# Altium schematic sheet TIDA-00367_REV2.SchDoc(TIDA-00367_REV2)
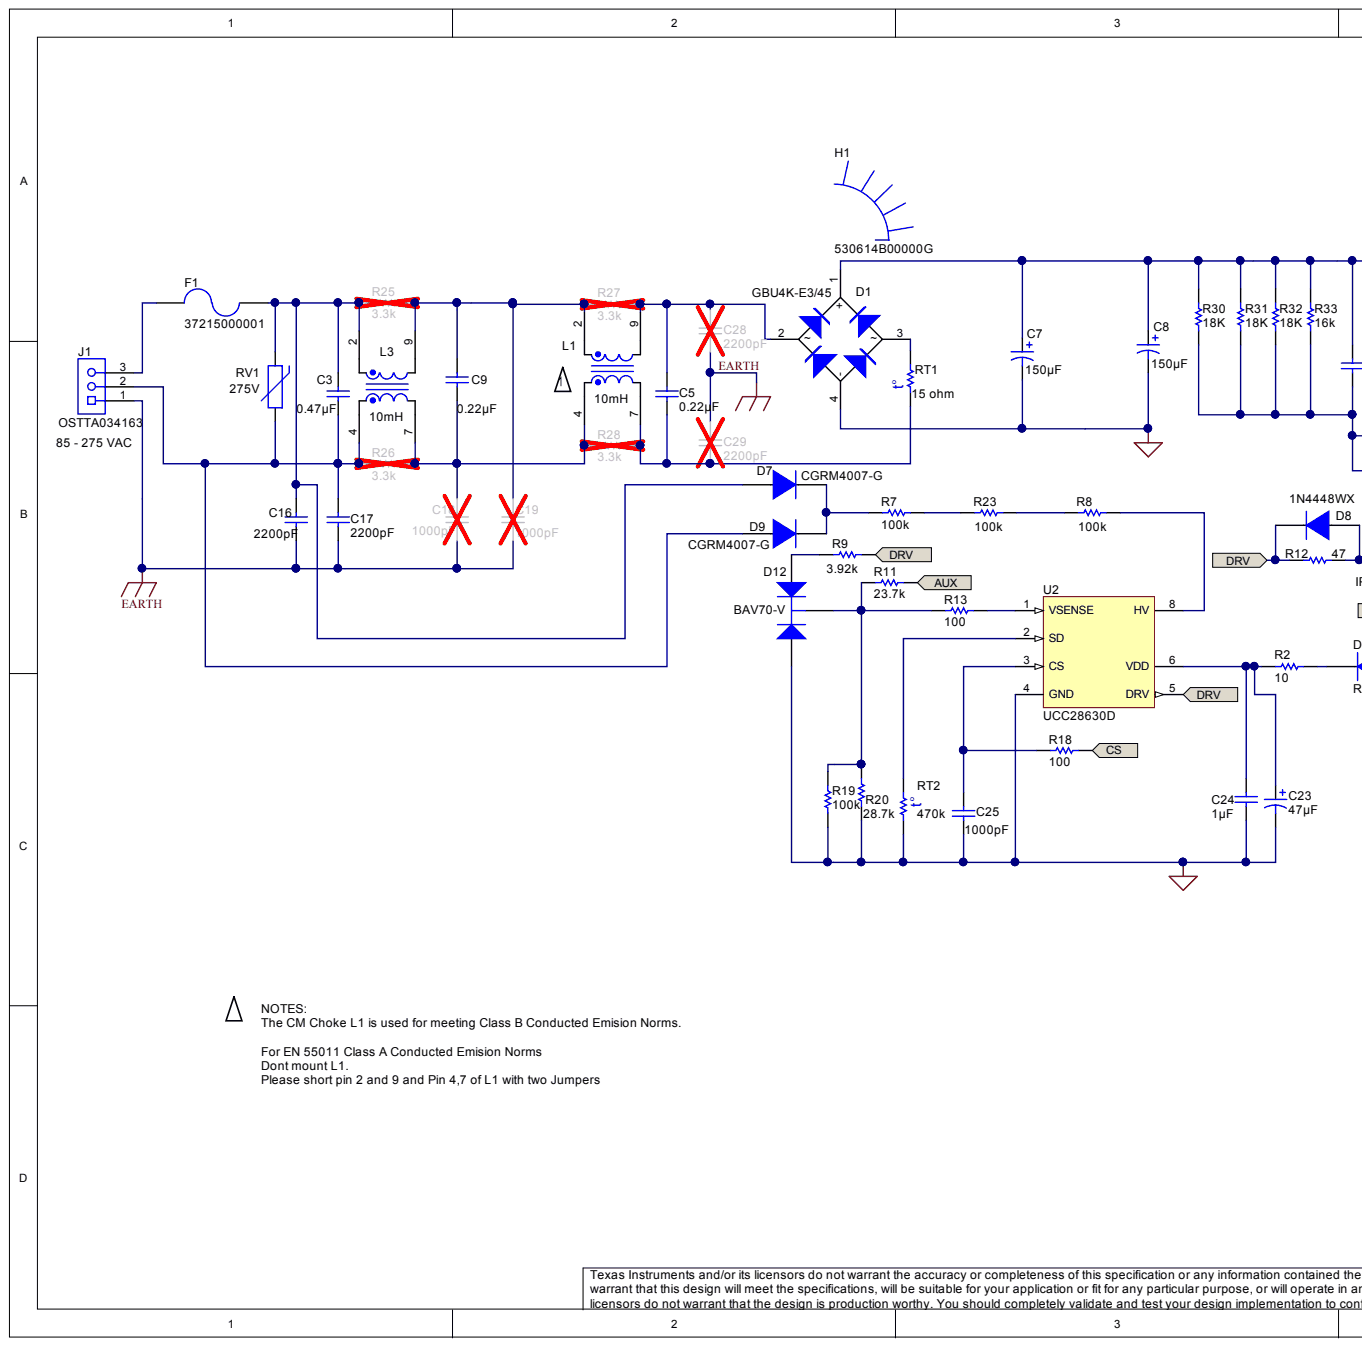
[diagram p0: TIDA-00367_REV2.SchDoc, left]
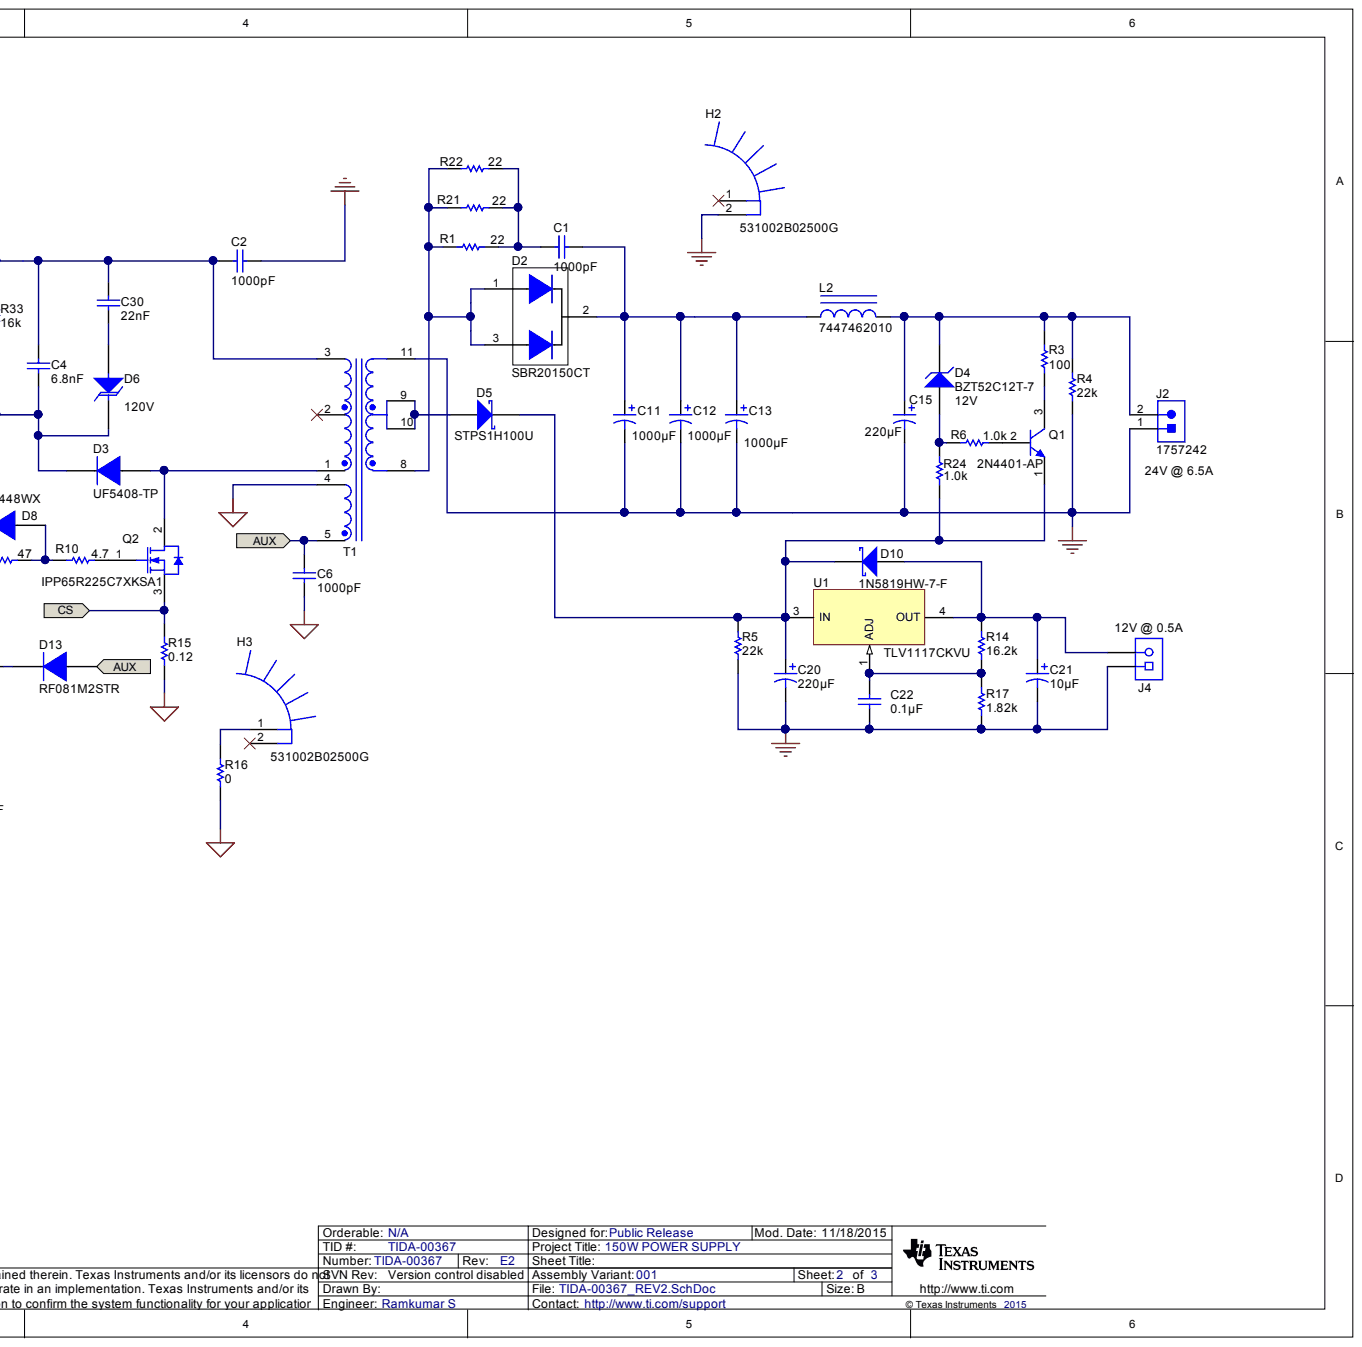
[diagram p1: TIDA-00367_REV2.SchDoc, right]

## Parts
C1  value 1000pF  [0805_HV]  (hidden comment: QMK212B7102KD-T)
C2  value 1000pF  [VY2_1250x500x1650]  (hidden comment: DE1E3KX102MA5BA01)
C3  ValueDisplayed: 0.47µF  [B32922_12.5mm]  (hidden comment: B32922C3474M)
C4  ValueDisplayed: 6.8nF  [VY2_1250x500x1650]  (hidden comment: RDER73A682K3K1H03B)
C5  ValueDisplayed: 0.22µF  [B32921_1300x1200x600]  (hidden comment: B32653A224J)
C6  value 1000pF  [1206]  (hidden comment: VJ1206A102JXGAT5Z)
C7  ValueDisplayed: 150µF  [CAPPRD750W80D1800H5200]  (hidden comment: 450BXW150MEFC18X45)
C8  ValueDisplayed: 150µF  [CAPPRD750W80D1800H5200]  (hidden comment: 450BXW150MEFC18X45)
C9  ValueDisplayed: 0.22µF  [B32922_12.5mm]  (hidden comment: B32922C3224M)
C11  ValueDisplayed: 1000µF  [FR_1250x2000]  (hidden comment: EEU-FR1V102B)
C12  ValueDisplayed: 1000µF  [FR_1250x2000]  (hidden comment: EEU-FR1V102B)
C13  ValueDisplayed: 1000µF  [FR_1250x2000]  (hidden comment: EEU-FR1V102B)
C15  ValueDisplayed: 220µF  [KY_800x1500]  (hidden comment: EKY-350ELL221MH15D)
C16  value 2200pF  [VY2_1250x500x1650]  (hidden comment: DE1E3KX222MA4BL01)
C17  value 2200pF  [VY2_1250x500x1650]  (hidden comment: DE1E3KX222MA4BL01)
C18  value 1000pF  [VY2_1250x500x1650]  (hidden comment: DE1E3KX102MA5BA01)
C19  value 1000pF  [VY2_1250x500x1650]  (hidden comment: DE1E3KX102MA5BA01)
C20  ValueDisplayed: 220µF  [KY_800x1500]  (hidden comment: EKY-350ELL221MH15D)
C21  ValueDisplayed: 10µF  [KS_400x500]  (hidden comment: ECE-A1CKS100)
C22  ValueDisplayed: 0.1µF  [0603]  (hidden comment: 06035C104KAT2A)
C23  ValueDisplayed: 47µF  [ZLJ_500x1100]  (hidden comment: 35ZLJ47MTA5X11)
C24  ValueDisplayed: 1µF  [1206]  (hidden comment: 08055C105KAT2A)
C25  value 1000pF  [0603]  (hidden comment: 08055C102KAT2A)
C28  value 2200pF  [VY2_1250x500x1650]  (hidden comment: DE1E3KX222MA4BL01)
C29  value 2200pF  [VY2_1250x500x1650]  (hidden comment: DE1E3KX222MA4BL01)
C30  value 22nF  [VY2_1250x500x1650]  (hidden comment: RDER73A223K3M1H03A)
D1  GBU4K-E3/45  [GBU]
D2  SBR20150CT  [TO-220AB]
D3  UF5408-TP  [DO-201AD]
D4  BZT52C12T-7  value 12V  [SOD-523]
D5  STPS1H100U  [SMB]
D6  value 120V  [DO-201AD_Vert]  (hidden comment: 1.5KE120A-E3/54)
D7  CGRM4007-G  [MINI-SMA]
D8  1N4448WX  [sod-323]
D9  CGRM4007-G  [MINI-SMA]
D10  1N5819HW-7-F  [SOD-123]
D12  BAV70-V  [SOT-23]
D13  RF081M2STR  [SOD-123]
F1  37215000001  [FUSE_372]
H1  530614B00000G  [Aavid_530614B00000G]
H2  531002B02500G  [HSINK_531002B02500G]
H3  531002B02500G  [HSINK_531002B02500G]
J1  OSTTA034163  [On-Shore_OSTTA034163]
J2  1757242  [PHOENIX_1786404]
J4  OSTTC022162  [On-Shore_OSTTC022162]  (value not drawn)
L1  value 10mH  [IND_WE-CMB_L]  (hidden comment: ALFT-04-9)
L2  7447462010  [WE-TI_5075]
L3  value 10mH  [IND_WE-CMB_L]  (hidden comment: ALFT-04-9)
Q1  2N4401-AP  [TO-92AP]
Q2  IPP65R225C7XKSA1  [TO-220_FullPAK_Offset]
R1  value 22  [1206]  (hidden comment: CRCW120622R0JNEA)
R2  value 10  [0603]  (hidden comment: CRCW060310R0JNEA)
R3  OF101JE  [CMF50]  (value not drawn)
R4  value 22k  [0603]  (hidden comment: CRCW060322K0JNEA)
R5  value 22k  [0603]  (hidden comment: CRCW060322K0JNEA)
R6  value 1.0k  [0805_HV]  (hidden comment: CRCW08051K00JNEA)
R7  value 100k  [1206]  (hidden comment: CRCW1206100KJNEA)
R8  value 100k  [1206]  (hidden comment: CRCW1206100KJNEA)
R9  value 3.92k  [1206]  (hidden comment: ERJ-6ENF3921V)
R10  value 4.7  Res_ohm: 4.7  [1206]  (hidden comment: ERJ-6GEYJ4R7V)
R11  value 23.7k  [0603]  (hidden comment: CRCW040223K7FKED)
R12  value 47  [1206]  (hidden comment: ERJ-6GEYJ470V)
R13  value 100  [0603]  (hidden comment: CRCW0805100RFKEA)
R14  value 16.2k  [0603]  (hidden comment: CRCW040216K2FKED)
R15  value 0.12  Res_ohm: 0.12  [2512_084]  (hidden comment: 2-2176057-7)
R16  value 0  [0603]  (hidden comment: CRCW06030000Z0EA)
R17  value 1.82k  [0603]  (hidden comment: CRCW04021K82FKED)
R18  value 100  [0603]  (hidden comment: CRCW0805100RFKEA)
R19  value 100k  [0603]  (hidden comment: CPF0603B100KE)
R20  value 28.7k  [0603]  (hidden comment: CRCW040228K7FKED)
R21  value 22  [1206]  (hidden comment: CRCW120622R0JNEA)
R22  value 22  [1206]  (hidden comment: CRCW120622R0JNEA)
R23  value 100k  [1206]  (hidden comment: CRCW1206100KJNEA)
R24  value 1.0k  [0805_HV]  (hidden comment: CRCW08051K00JNEA)
R25  value 3.3k  [0805_HV]  (hidden comment: CRCW08053K30JNEA)
R26  value 3.3k  [0805_HV]  (hidden comment: CRCW08053K30JNEA)
R27  value 3.3k  [0805_HV]  (hidden comment: CRCW08053K30JNEA)
R28  value 3.3k  [0805_HV]  (hidden comment: CRCW08053K30JNEA)
R30  value 18K  [ERG3S]  (hidden comment: ERG-3SJ183)
R31  value 18K  [ERG3S]  (hidden comment: ERG-3SJ183)
R32  value 18K  [ERG3S]  (hidden comment: ERG-3SJ183)
R33  value 16k  [ERG3S]  (hidden comment: ERG-3SJ163)
RT1  value 15 ohm  [S153]  (hidden comment: B57153S150M)
RT2  value 470k  [THERM_B57164K]  (hidden comment: B57164K474J)
RV1  value 275V  [VAR_B72214S0271]  (hidden comment: B72214S0271K101)
T1  750342946  [Wurth_750342946]  (value not drawn)
U1  TLV1117CKVU  [KVU0003A_N]
U2  UCC28630D  [D0007A_N]

## Ports
AUX, CS, DRV

## Nets
GND: C2.2 C11.2 C12.2 C13.2 C15.2 C20.2 C21.2 C22.2 H2.2 J2.1 J4.1 R4.1 R5.1 R17.1 T1.11
PGND: C6.2 C7.2 C8.2 C23.2 C24.2 C25.2 D1.4(-) D12.1 R15.1 R16.1 R19.1 R20.2 RT2.1 T1.4 U2.4(GND)
AUX: C6.1 D13.2 R11.1 T1.5 ; port AUX
CS: Q2.3 R15.2 R18.2 ; port CS
DRV: D8.1 R9.1 R12.2 U2.5(DRV) ; port DRV
EARTH: C16.2 C17.2 C18.2 C19.2 C28.2 C29.1 J1.1
NetC1_1: C1.1 C11.1 C12.1 C13.1 D2.2 L2.1
NetC1_2: C1.2 R1.2 R21.2 R22.2
NetC2_1: C2.1 C4.1 C7.1 C8.1 C30.1 D1.1(+) R30.2 R31.2 R32.2 R33.2 T1.3
NetC3_1: C3.1 C16.1 D7.2 F1.1 L3.2 R25.1 RV1.2
NetC3_2: C3.2 C17.1 D9.2 J1.2 L3.4 R26.1 RV1.1
NetC4_2: C4.2 D3.1 D6.1 R30.1 R31.1 R32.1 R33.1
NetC5_1: C5.1 C28.1 D1.2(~) L1.9 R27.2
NetC5_2: C5.2 C29.2 L1.7 R28.2 RT1.2
NetC9_1: C9.1 C19.1 L1.2 L3.9 R25.2 R27.1
NetC9_2: C9.2 C18.1 L1.4 L3.7 R26.2 R28.1
NetC15_1: C15.1 D4.1 J2.2 L2.2 R3.2 R4.2
NetC20_1: C20.1 D5.1 D10.1 Q1.1 R5.2 R24.1 U1.3
NetC21_1: C21.1 D10.2 J4.2 R14.2 U1.4
NetC22_1: C22.1 R14.1 R17.2 U1.1
NetC23_1: C23.1 C24.1 R2.1 U2.6(VDD)
NetC25_1: C25.1 R18.1 U2.3(CS)
NetC30_2: C30.2 D6.2
NetD1_3: D1.3(~) RT1.1
NetD2_1: D2.1 D2.3 R1.1 R21.1 R22.1 T1.8
NetD3_2: D3.2 Q2.2 T1.1
NetD4_2: D4.2 R6.1 R24.2
NetD5_2: D5.2 T1.9 T1.10
NetD7_1: D7.1 D9.1 R7.1
NetD8_2: D8.2 R10.2 R12.1
NetD12_2: D12.2 R9.2
NetD12_3: D12.3 R11.2 R13.1 R19.2 R20.1
NetD13_1: D13.1 R2.2
NetF1_2: F1.2 J1.3
NetH3_1: H3.1 R16.2
NetQ1_2: Q1.2 R6.2
NetQ1_3: Q1.3 R3.1
NetQ2_1: Q2.1 R10.1
NetR7_2: R7.2 R23.1
NetR8_1: R8.1 R23.2
NetR8_2: R8.2 U2.8(HV)
NetR13_2: R13.2 U2.1(VSENSE)
NetRT2_2: RT2.2 U2.2(SD)

Not connected: H2 1; H3 2; T1 2
